# Altium schematic sheet MCU.SchDoc(MCU)
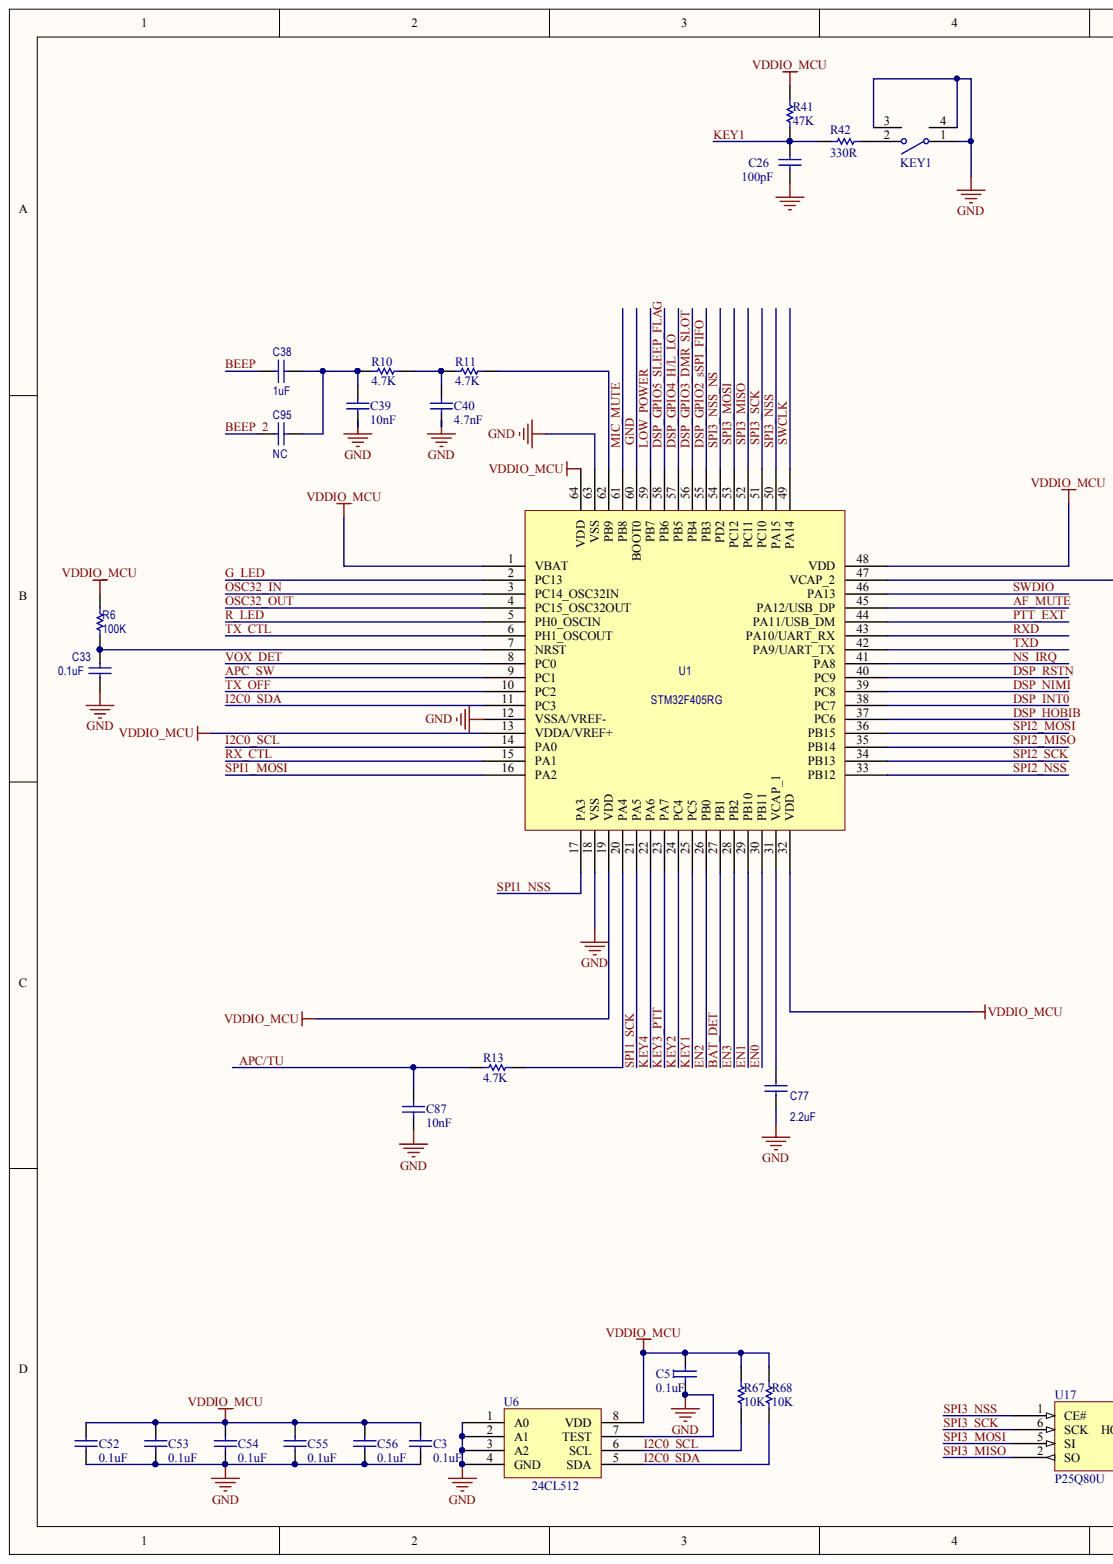
[diagram p0: MCU.SchDoc, left]
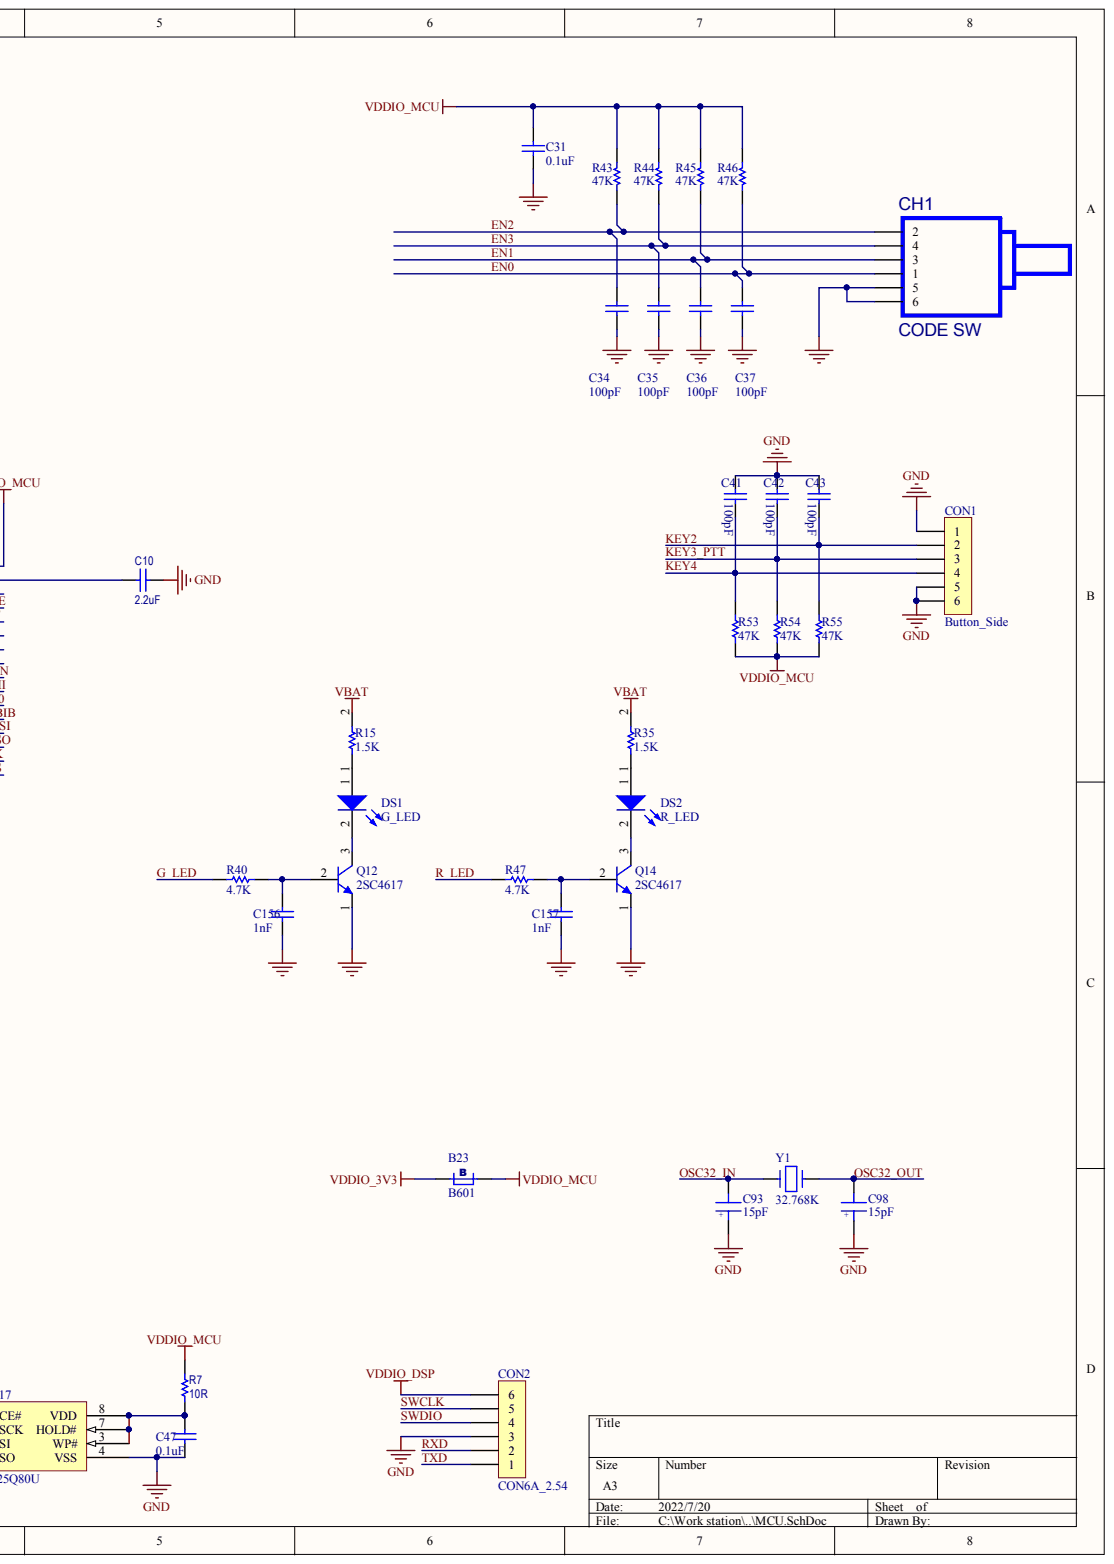
[diagram p1: MCU.SchDoc, right]

## Parts
B23  B601  [0402]
C3  0.1uF  [0402]
C10  2.2uF  [0603]
C26  100pF  [0402]
C31  0.1uF  [0402]
C33  0.1uF  [0402]
C34  100pF  [0402]
C35  100pF  [0402]
C36  100pF  [0402]
C37  100pF  [0402]
C38  1uF  [0402]
C39  10nF  [0402]
C40  4.7nF  [0402]
C41  100pF  [0402]
C42  100pF  [0402]
C43  100pF  [0402]
C47  0.1uF  [0402]
C51  0.1uF  [0402]
C52  0.1uF  [0402]
C53  0.1uF  [0402]
C54  0.1uF  [0402]
C55  0.1uF  [0402]
C56  0.1uF  [0402]
C77  2.2uF  [0603]
C87  10nF  [0402]
C93  15pF  [0402]
C95  NC  [0402]
C98  15pF  [0402]
C156  1nF  [0402_MJ]
C157  1nF  [0402_MJ]
CH1  CODE SW  [SW-2X3]
CON1  Button_Side  [Button_Side]
CON2  CON6A_2.54  [HDR1X6]
DS1  G_LED  [0603_led]
DS2  R_LED  [0603_led]
KEY1  ANK-2.5X7-D  [ANK-2.5X7-D]  (value not drawn)
Q12  2SC4617  [SOT-416]
Q14  2SC4617  [SOT-416]
R6  100K  [0402]
R7  10R  [0402]
R10  4.7K  [0402]
R11  4.7K  [0402]
R13  4.7K  [0402]
R15  1.5K  [0402_MJ]
R35  1.5K  [0402_MJ]
R40  4.7K  [0402_MJ]
R41  47K  [0402]
R42  330R  [0402]
R43  47K  [0402]
R44  47K  [0402]
R45  47K  [0402]
R46  47K  [0402]
R47  4.7K  [0402_MJ]
R53  47K  [0402]
R54  47K  [0402]
R55  47K  [0402]
R67  10K  [0402]
R68  10K  [0402]
U1  STM32F405RG  [LQFP64]
U6  24CL512  [SOP-8-1.27]
U17  P25Q80U  [SOP-8-1.27]
Y1  32.768K  [3215]

## Nets
VBAT: R15.2 R35.2
VDDIO_3V3: B23.2
VDDIO_DSP: CON2.6
VDDIO_MCU: B23.1 C3.2 C31.1 C51.1 C52.2 C53.2 C54.2 C55.2 C56.2 R6.1 R7.2 R41.1 R43.2 R44.2 R45.2 R46.2 R53.2 R54.2 R55.2 R67.2 R68.2 U1.1(VBAT) U1.13(VDDA/VREF+) U1.19(VDD) U1.32(VDD) U1.48(VDD) U1.64(VDD) U6.8(VDD)
AF_MUTE: U1.45(PA12/USB_DP)
APC/TU: C87.1 R13.2
APC_SW: U1.9(PC1)
BAT_DET: U1.27(PB1)
BEEP: C38.2
BEEP_2: C95.2
DSP_GPIO2_SSPI_FIFO: U1.55(PB3)
DSP_GPIO3_DMR_SLOT: U1.56(PB4)
DSP_GPIO4_H/L_LO: U1.57(PB5)
DSP_GPIO5_SLEEP_FLAG: U1.58(PB6)
DSP_HOBIB: U1.37(PC6)
DSP_INT0: U1.38(PC7)
DSP_NIMI: U1.39(PC8)
DSP_RSTN: U1.40(PC9)
EN0: C37.1 CH1.1 R46.1 U1.30(PB11)
EN1: C36.1 CH1.3 R45.1 U1.29(PB10)
EN2: C34.1 CH1.2 R43.1 U1.26(PB0)
EN3: C35.1 CH1.4 R44.1 U1.28(PB2)
G_LED: R40.2 U1.2(PC13)
GND: C3.1 C10.2 C26.2 C31.2 C33.2 C34.2 C35.2 C36.2 C37.2 C39.2 C40.2 C41.2 C42.2 C43.2 C47.2 C51.2 C52.1 C53.1 C54.1 C55.1 C56.1 C77.2 C87.2 C93.1 C98.1 C156.2 C157.2 CH1.5 CH1.6 CON1.1 CON1.5 CON1.6 CON2.3 KEY1.1 KEY1.3 KEY1.4 Q12.1 Q14.1 U1.12(VSSA/VREF-) U1.18(VSS) U1.60(BOOT0) U1.63(VSS) U6.1(A0) U6.2(A1) U6.3(A2) U6.4(GND) U6.7(TEST) U17.4(VSS)
I2C0_SCL: R67.1 U1.14(PA0) U6.6(SCL)
I2C0_SDA: R68.1 U1.11(PC3) U6.5(SDA)
KEY1: C26.1 R41.2 R42.1 U1.25(PC5)
KEY2: C43.1 CON1.2 R55.1 U1.24(PC4)
KEY3_PTT: C42.1 CON1.3 R54.1 U1.23(PA7)
KEY4: C41.1 CON1.4 R53.1 U1.22(PA6)
LOW_POWER: U1.59(PB7)
MIC_MUTE: U1.61(PB8)
NS_IRQ: U1.41(PA8)
OSC32_IN: C93.2 U1.3(PC14_OSC32IN) Y1.1
OSC32_OUT: C98.2 U1.4(PC15_OSC32OUT) Y1.2
PTT_EXT: U1.44(PA11/USB_DM)
R_LED: R47.2 U1.5(PH0_OSCIN)
RX_CTL: U1.15(PA1)
RXD: CON2.2 U1.43(PA10/UART_RX)
SPI1_MOSI: U1.16(PA2)
SPI1_NSS: U1.17(PA3)
SPI1_SCK: U1.21(PA5)
SPI2_MISO: U1.35(PB14)
SPI2_MOSI: U1.36(PB15)
SPI2_NSS: U1.33(PB12)
SPI2_SCK: U1.34(PB13)
SPI3_MISO: U1.52(PC11) U17.2(SO)
SPI3_MOSI: U1.53(PC12) U17.5(SI)
SPI3_NSS: U1.50(PA15) U17.1(CE#)
SPI3_NSS_NS: U1.54(PD2)
SPI3_SCK: U1.51(PC10) U17.6(SCK)
SWCLK: CON2.5 U1.49(PA14)
SWDIO: CON2.4 U1.46(PA13)
TX_CTL: U1.6(PH1_OSCOUT)
TX_OFF: U1.10(PC2)
TXD: CON2.1 U1.42(PA9/UART_TX)
VOX_DET: U1.8(PC0)
NetC10_1: C10.1 U1.47(VCAP_2)
NetC33_1: C33.1 R6.2 U1.7(NRST)
NetC38_1: C38.1 C39.1 C95.1 R10.2
NetC40_1: C40.1 R10.1 R11.2
NetC47_1: C47.1 R7.1 U17.3(WP#) U17.7(HOLD#) U17.8(VDD)
NetC77_1: C77.1 U1.31(VCAP_1)
NetC156_1: C156.1 Q12.2 R40.1
NetC157_1: C157.1 Q14.2 R47.1
NetDS1_1: DS1.1 R15.1
NetDS1_2: DS1.2 Q12.3
NetDS2_1: DS2.1 R35.1
NetDS2_2: DS2.2 Q14.3
NetKEY1_2: KEY1.2 R42.2
NetR11_1: R11.1 U1.62(PB9)
NetR13_1: R13.1 U1.20(PA4)

## Library data of the parts
B23 = B: Component Kind: Standard; Library Name: SCH Lib.SCHLIB; Revision: July-2002: Re-released for DXP Platform.
C3 C31 C33 C47 C51-C56 = Cap Semi: Capacitor (Semiconductor SIM Model); Component Kind: Standard; Library Name: Miscellaneous Devices.IntLib; Published: 8-Jun-2000; Revision: July-2002: Re-released for DXP Platform.; Signal Integrity: Cap Semi; Simulation: CAP; Value: 0.1uF; Publisher: Altium Limited
C10 C26 C34-C43 C77 C87 C95 C156 C157 = Cap Semi: Capacitor (Semiconductor SIM Model); Value: 0.1uF; Component Kind: Standard; Library Name: Miscellaneous Devices.IntLib; Published: 8-Jun-2000; Revision: July-2002: Re-released for DXP Platform.; Signal Integrity: Cap Semi; Simulation: CAP; Publisher: Altium Limited
C93 C98 = CAP_0603: Component Kind: Standard; Library Name: zySCHLIB.SCHLIB
DS1 DS2 = LED2: Typical RED, GREEN, YELLOW, AMBER GaAs LED; Manufacturer: Generic Components; Class: Optoelectronic; Component Kind: Standard; Library Name: Miscellaneous Devices.IntLib; Published: 08/06/2000; Simulation: LED2; SubClass: LED; Publisher: Altium Hobart Technology Centre
KEY1 = SW-SPST_Jun: Single-Pole, Single-Throw Switch; Manufacturer: Generic Components; Component Kind: Standard; Published: 1999; Library Name: zySCHLIB_Z.SCHLIB
Q12 Q14 = NPN_2SC4617: Code_JEDEC: TO-226-AA; Component Kind: Standard; LatestRevisionDate: 17-Jul-2002; LatestRevisionNote: Re-released for DXP Platform.; PackageReference: TO-226; Published: 8-Jun-2000; Signal Integrity: NPN; Simulation: NPN; Library Name: zySCHLIB_Z.SCHLIB; Publisher: Altium Limited
R6 R7 = Res1: Resistor; Manufacturer: Generic Components; Class: Passive; Value: 1K; Component Kind: Standard; Library Name: RF.SCHLIB; Published: 08/06/2000; Signal Integrity: Res1; Simulation: RESISTOR; SubClass: Resistor; Publisher: Altium Hobart Technology Centre
R10 R11 R13 R15 R35 R40-R47 R53-R55 R67 R68 = Res Semi: Semiconductor Resistor; Manufacturer: Generic Components; Class: Passive; Value: 1K; Component Kind: Standard; Library Name: Miscellaneous Devices.IntLib; Published: 08/06/2000; Signal Integrity: Res Semi; Simulation: RES; SubClass: Resistor; Publisher: Altium Hobart Technology Centre
U1 = STM32F411: Component Kind: Standard; Library Name: SCH Lib.SCHLIB
U6 = 24LC08: 串口E2PROM; Component Kind: Standard; Library Name: SCH Lib.SCHLIB
U17 = SST25VF016: 16Mbit SPI 串行Flash; Component Kind: Standard; Library Name: SCH Lib.SCHLIB
Y1 = CRYSTAL: 晶振; Component Kind: Standard; Library Name: Component.SchLib
CH1 = CODE SW: Component Kind: Standard; Library Name: zySCHLIB_Z.SCHLIB
CON1 = Button_Side: Component Kind: Standard; Library Name: zySCHLIB_Z.SCHLIB
CON2 = CON6A_2.54: Component Kind: Standard; Library Name: zySCHLIB_Z.SCHLIB
